# Altium schematic sheet Air_quality_5_click_v101.SchDoc(Air_quality_5_click_v101)
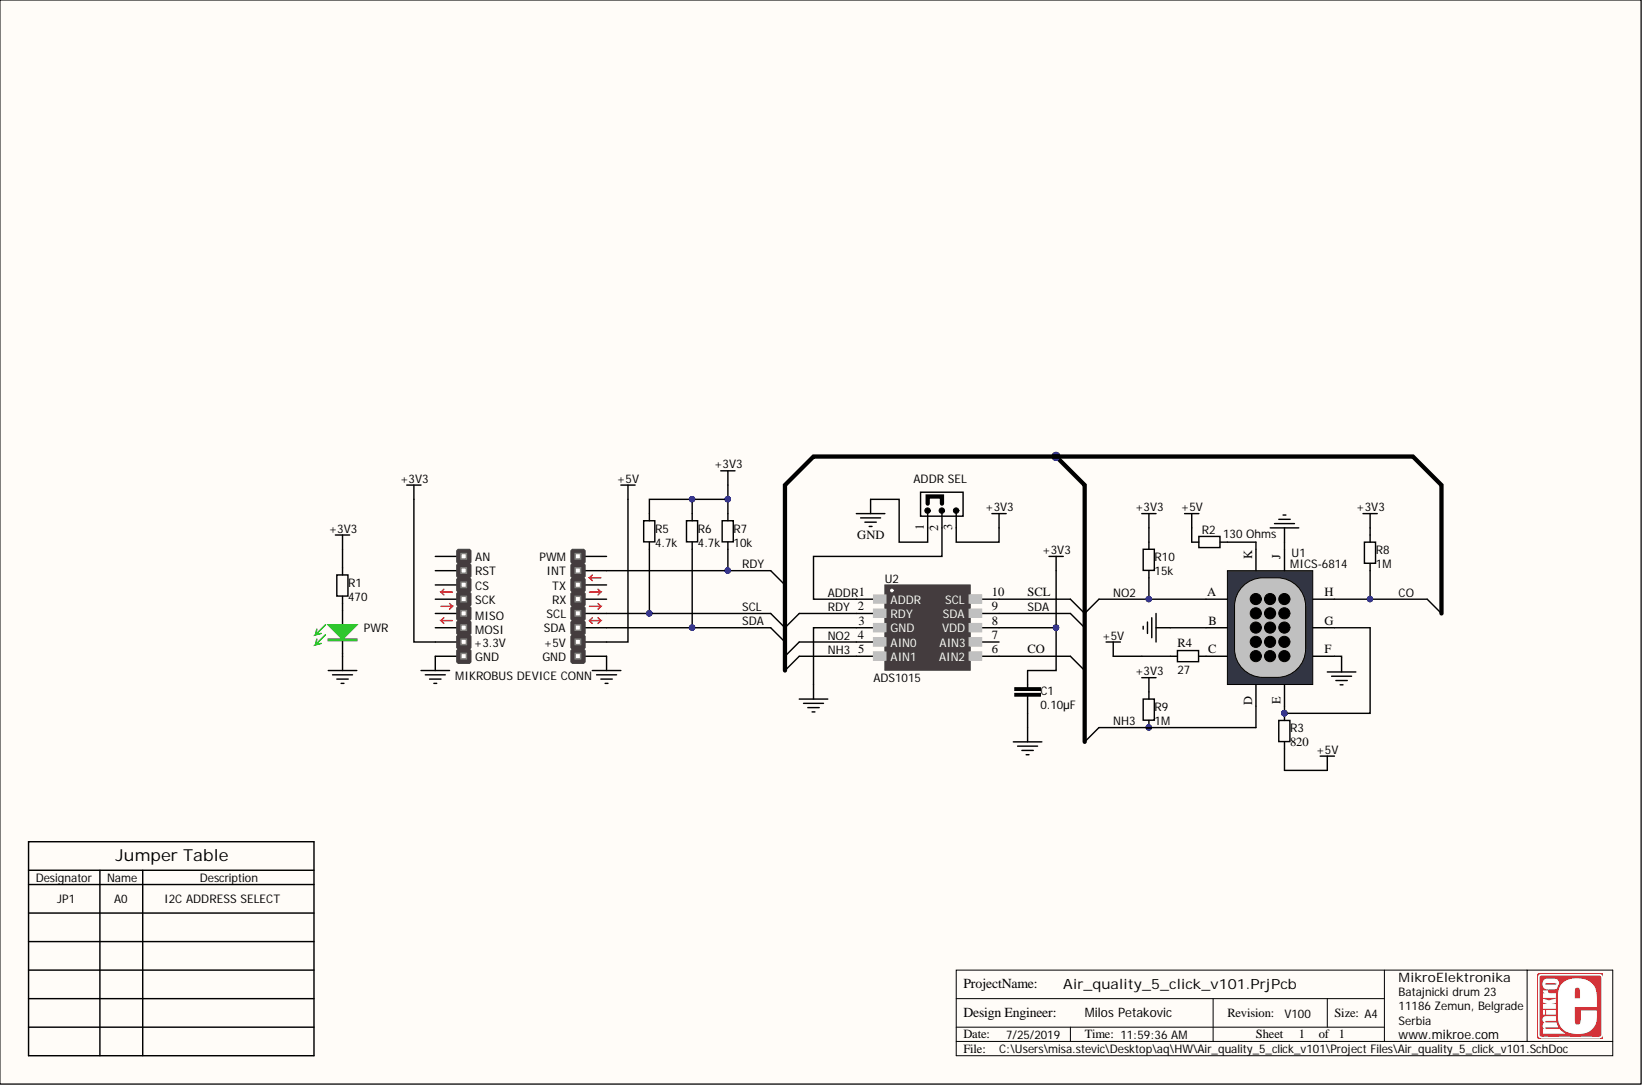
[diagram p0: Air_quality_5_click_v101.SchDoc]

## Parts
C1  0.10µF  [CAPC1005X55N-0402-NoOverlay]
JP1  ADDR SEL  [JUMPER-0805-V1-NoOverlay]
MBD1  MIKROBUS DEVICE CONN  [New Click Template_MIDI-SG]  (no BOM)
PWR  LED GREEN  [DIOGREENC2013X120N-0805-NoOverlay]  (value not drawn)
R1  470  [RESC2013X38N-0805-NOOVERLAY]
R2  130 Ohms  [RESC1508X55N-0603-NOOVERLAY]
R3  820  [RESC1508X55N-0603-NOOVERLAY]
R4  27  [RESC1508X55N-0603-NOOVERLAY]
R5  4.7k  [RESC2013X38N-0805-NOOVERLAY]
R6  4.7k  [RESC2013X38N-0805-NOOVERLAY]
R7  10k  [RESC2013X38N-0805-NOOVERLAY]
R8  1M  [RESC1508X55N-0603-NOOVERLAY]
R9  1M  [RESC1508X55N-0603-NOOVERLAY]
R10  15k  [RESC2013X38N-0805-NOOVERLAY]
U1  MICS-6814  [MICS-6814]
U2  ADS1015  [QFN50P200X150X40-10N]

## Nets
+3V3: C1.2 JP1.3 MBD1.7(+3.3V) R1.2 R5.2 R6.2 R7.2 R8.2 R9.2 R10.2 U2.8(VDD)
+5V: MBD1.10(+5V) R2.2 R3.1 R4.1
GND: C1.1 JP1.1 MBD1.8(GND) MBD1.9(GND) PWR.1 U1.B U1.F U1.J U2.3(GND)
ADDR: JP1.2 U2.1(ADDR)
CO: R8.1 U1.H U2.6(AIN2)
NH3: R9.1 U1.D U2.5(AIN1)
NO2: R10.1 U1.A U2.4(AIN0)
RDY: MBD1.15(INT) R7.1 U2.2(RDY)
SCL: MBD1.12(SCL) R5.1 U2.10(SCL)
SDA: MBD1.11(SDA) R6.1 U2.9(SDA)
NetPWR_2: PWR.2 R1.1
NetR2_1: R2.1 U1.K
NetR3_2: R3.2 U1.E U1.G
NetR4_2: R4.2 U1.C
N00020:

Not connected: MBD1 1(AN) 2(RST) 3(CS) 4(SCK) 5(MISO) 6(MOSI) 13(RX) 14(TX) 16(PWM); U2 7(AIN3)

## Library data of the parts
C1 = 0100000098059: CAP CER 0.1UF 16V X7R 0402; Manufacturer Part Number: CL05B104KO5NNNC; Manufacturer: Samsung Electro-Mechanics America, Inc.; Tolerance: ±10%; item_id: 8919; id: 219; Database Table Name: capacitors; Assembly: SMD; Voltage Rated: 16V; Temperature Coefficient: X7R; Part Type: Capacitors; Package Case: 0402 (1005 Metric); Library Name: ME-Altium Lib.SVNDbLib; Family: CERAMIC CAPACITOR; Datasheet URL: http://www.samsungsem.com/kr/support/product-search/mlcc/CL05B104KO5NNNC.jsp; Component Kind: Standard; Category: Capacitors; Capacitance: 0.10µF; Barcode: 0100000098059
JP1 = SEL JMP 0805 SMD: Manufacturer Part Number: RC0805JR-070RL; Manufacturer: Yageo; Tolerance: Jumper; Resistance: 0.0; Power: 0.125W, 1/8W; Barcode: 0100000003947; Assembly: SMD; Temperature Coefficient: -; Part Type: Resistors; Package Case: 0805 (2012 Metric); Library Name: ME-Integrated_Library.IntLib; Datasheet URL: http://www.yageo.com/NewPortal/yageodocoutput?fileName=/pdf/R-Chip/PYu-RC_Group_51_RoHS_L_3.pdf; Component Kind: Standard; Category: Resistors
MBD1 = MIKROBUS DEVICE CONN: mikroBUS Target Header; Library Name: ME-Integrated_Library.IntLib
PWR = 2911010024338: LED GREEN CLEAR 0805 SMD; Manufacturer Part Number: APT2012SGC; Manufacturer: Kingbright; Wavelength: 568nm; Voltage Forward: 2.2V; Recommended Rating: Platinum; Lens Color: Colorless; item_id: 3342; id: 28; Database Table Name: optoelectronics; Part Type: Optoelectronics; Package Case: 0805 (2012 Metric); Library Name: ME-Altium Lib.SVNDbLib; Lens Transparency: Clear; Family: LED; Datasheet URL: http://www.kingbrightusa.com/images/catalog/SPEC/APT2012SGC.pdf; Component Kind: Standard; Color: Green; Category: Optoelectronics; Barcode: 2911010024338; Assembly: SMD
R1 = 0100000007921: RES SMD 470 OHM 1% 1/8W 0805; Manufacturer Part Number: RC0805FR-07470RL; Manufacturer: Yageo; Temperature Coefficient: ±100ppm/°C; Recommended Rating: Platinum; Power: 0.125W, 1/8W; item_id: 1082; id: 207; Database Table Name: resistors; Tolerance: ±1%; Resistance: 470; Part Type: Resistors; Package Case: 0805 (2012 Metric); Library Name: ME-Altium Lib.SVNDbLib; Family: RESISTOR; Datasheet URL: http://www.yageo.com/NewPortal/yageodocoutput?fileName=/pdf/R-Chip/PYu-RC_Group_51_RoHS_L_3.pdf; Component Kind: Standard; Category: Resistors; Barcode: 0100000007921; Assembly: SMD
R2 = 3500000181152: RES SMD 130 OHM 0.1% 1/10W 0603; Manufacturer Part Number: ERA-3AEB131V; Manufacturer: Panasonic Electronic Components; Temperature Coefficient: ±25ppm/°C; Power: 0.1W, 1/10W; item_id: 16250; id: 966; Database Table Name: resistors; Tolerance: ±0.1%; Resistance: 130 Ohms; Part Type: Resistors; Package Case: 0603 (1608 Metric); Library Name: ME-Altium Lib.SVNDbLib; Family: RESISTOR; Datasheet URL: https://industrial.panasonic.com/cdbs/www-data/pdf/RDM0000/AOA0000C307.pdf; Component Kind: Standard; Category: Resistors; Barcode: 3500000181152; Assembly: SMD
R3 = 0100000109267: RES SMD 820 OHM 1% 1/10W 0603; Manufacturer Part Number: RC0603FR-07820RL; Manufacturer: Yageo; Temperature Coefficient: ±100ppm/°C; Power: 0.1W, 1/10W; item_id: 10040; id: 390; Database Table Name: resistors; Tolerance: ±1%; Resistance: 820; Part Type: Resistors; Package Case: 0603 (1608 Metric); Library Name: ME-Altium Lib.SVNDbLib; Family: RESISTOR; Datasheet URL: http://www.yageo.com/documents/recent/PYu-RC_Group_51_RoHS_L_04.pdf; Component Kind: Standard; Category: Resistors; Barcode: 0100000109267; Assembly: SMD
R4 = 0100000013465: RES SMD 27 OHM 1% 1/10W 0603; Manufacturer Part Number: RC0603FR-0727RL; Manufacturer: Yageo; Temperature Coefficient: ±100ppm/°C; Recommended Rating: Platinum; Power: 0.1W, 1/10W; item_id: 1764; id: 110; Database Table Name: resistors; Tolerance: ±1%; Resistance: 27; Part Type: Resistors; Package Case: 0603 (1608 Metric); Library Name: ME-Altium Lib.SVNDbLib; Family: RESISTOR; Datasheet URL: http://www.yageo.com/NewPortal/yageodocoutput?fileName=/pdf/R-Chip/PYu-RC_Group_51_RoHS_L_3.pdf; Component Kind: Standard; Category: Resistors; Barcode: 0100000013465; Assembly: SMD
R5 R6 = 0100000002056: RES SMD 4.7K OHM 1% 1/8W 0805; Manufacturer Part Number: RC0805FR-074K7L; Manufacturer: Yageo; Temperature Coefficient: ±100ppm/°C; Recommended Rating: Platinum; Power: 0.125W, 1/8W; item_id: 470; id: 221; Database Table Name: resistors; Tolerance: ±1%; Resistance: 4.7k; Part Type: Resistors; Package Case: 0805 (2012 Metric); Library Name: ME-Altium Lib.SVNDbLib; Family: RESISTOR; Datasheet URL: http://www.yageo.com/NewPortal/yageodocoutput?fileName=/pdf/R-Chip/PYu-RC_Group_51_RoHS_L_3.pdf; Component Kind: Standard; Category: Resistors; Barcode: 0100000002056; Assembly: SMD
R7 = 0100000001974: RES SMD 10K OHM 1% 1/8W 0805; Manufacturer Part Number: RC0805FR-0710KL; Manufacturer: Yageo; Temperature Coefficient: ±100ppm/°C; Recommended Rating: Platinum; Power: 0.125W, 1/8W; item_id: 462; id: 228; Database Table Name: resistors; Tolerance: ±1%; Resistance: 10k; Part Type: Resistors; Package Case: 0805 (2012 Metric); Library Name: ME-Altium Lib.SVNDbLib; Family: RESISTOR; Datasheet URL: http://www.yageo.com/NewPortal/yageodocoutput?fileName=/pdf/R-Chip/PYu-RC_Group_51_RoHS_L_3.pdf; Component Kind: Standard; Category: Resistors; Barcode: 0100000001974; Assembly: SMD
R8 R9 = 0100000012925: RES SMD 1M OHM 1% 1/10W 0603; Manufacturer Part Number: MCR03ERTF1004; Manufacturer: Rohm Semiconductor; Temperature Coefficient: ±100ppm/°C; Recommended Rating: Gold; Power: 0.1W, 1/10W; item_id: 1710; id: 116; Database Table Name: resistors; Tolerance: ±1%; Resistance: 1M; Part Type: Resistors; Package Case: 0603 (1608 Metric); Library Name: ME-Altium Lib.SVNDbLib; Family: RESISTOR; Datasheet URL: http://rohmfs.rohm.com/en/products/databook/datasheet/passive/resistor/chip_resistor/mcr_g.pdf; Component Kind: Standard; Category: Resistors; Barcode: 0100000012925; Assembly: SMD
R10 = 2911010029241: RES SMD 15K OHM 1% 1/8W 0805; Manufacturer Part Number: RC0805FR-0715KL; Manufacturer: Yageo; Temperature Coefficient: ±100ppm/°C; Recommended Rating: Silver; Power: 0.125W, 1/8W; item_id: 3833; id: 185; Database Table Name: resistors; Tolerance: ±1%; Resistance: 15k; Part Type: Resistors; Package Case: 0805 (2012 Metric); Library Name: ME-Altium Lib.SVNDbLib; Family: RESISTOR; Datasheet URL: http://www.yageo.com/NewPortal/yageodocoutput?fileName=/pdf/R-Chip/PYu-RC_Group_51_RoHS_L_3.pdf; Component Kind: Standard; Category: Resistors; Barcode: 2911010029241; Assembly: SMD
U1 = 3500000173591: Manufacturer: Amphenol SGX Sensortech; item_id: 15494; id: 1717; Database Table Name: integrated_circuits; Assembly: SMD; Type: Air Quality Sensor; Part Type: Integrated Circuits; Manufacturer Part Number: MICS-6814; Library Name: ME-Altium Lib.SVNDbLib; Family: Gas Sensors; Datasheet URL: https://www.mouser.com/ds/2/18/1143_Datasheet-MiCS-6814-rev-8-1144828.pdf; Component Kind: Standard; Barcode: 3500000173591
U2 = 3500000173577: IC ADC 12BIT I2C INTRFC 10QFN; Manufacturer Part Number: ADS1015IRUGT; Manufacturer: Texas Instruments; Voltage  Supply Digital: 2 V ~ 5.5 V; Reference Type: Internal; Number of Bits: 12; item_id: 15492; Input Type: Differential, Single Ended; id: 1716; Data Interface: I²C; Database Table Name: integrated_circuits; Configuration: MUX-PGA-ADC; Assembly: SMD; Architecture: Sigma-Delta; Voltage  Supply Analog: 2 V ~ 5.5 V; Part Type: Integrated Circuits; Package Case: 10-XFQFN; Library Name: ME-Altium Lib.SVNDbLib; Features: PGA, Selectable Address; Family: Analog to Digital Converters (ADC); Datasheet URL: http://www.ti.com/lit/ds/symlink/ads1013.pdf; Component Kind: Standard; Category: Integrated Circuits (ICs); Barcode: 3500000173577
